# Altium schematic sheet IO_Expander.SchDoc(IO_Expander)
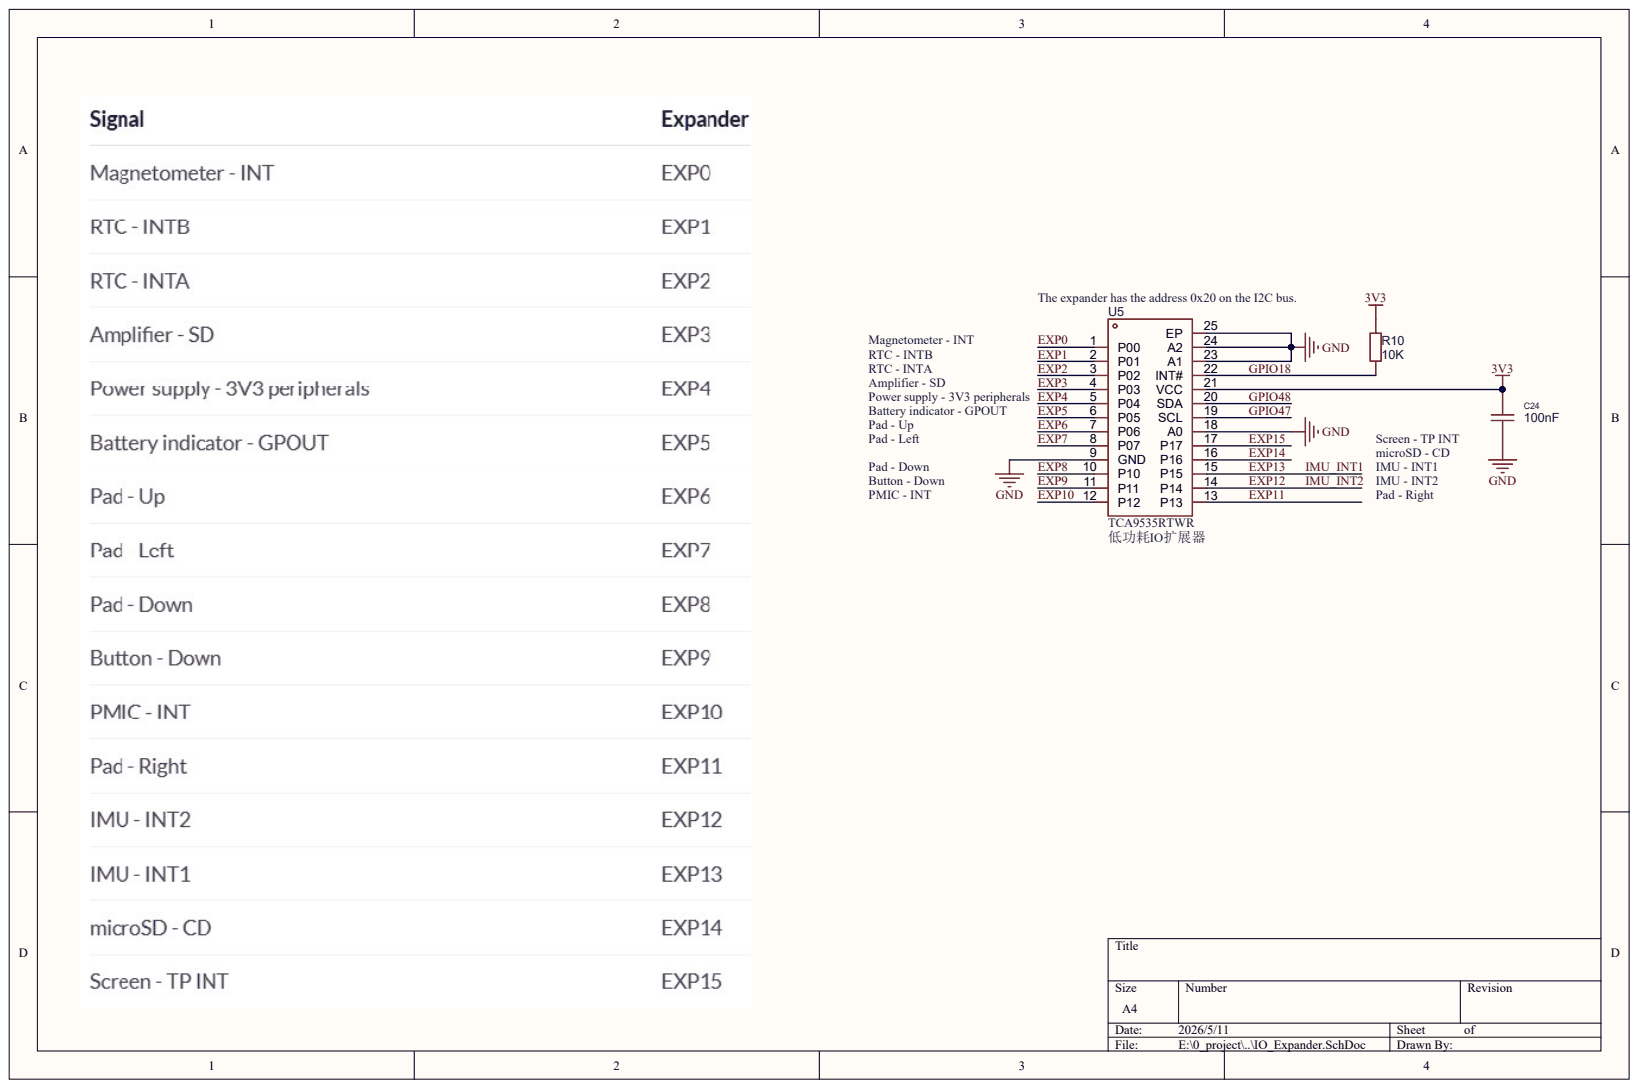
[diagram p0: IO_Expander.SchDoc]

## Parts
C24  100nF
R10  10K
U5  TCA9535RTWR

## Nets
3V3: C24.2 R10.2 U5.21(VCC)
GND: C24.1 U5.9(GND) U5.18(A0) U5.23(A1) U5.24(A2) U5.25(EP)
EXP0: U5.1(P00)
EXP1: U5.2(P01)
EXP2: U5.3(P02)
EXP3: U5.4(P03)
EXP4: U5.5(P04)
EXP5: U5.6(P05)
EXP6: U5.7(P06)
EXP7: U5.8(P07)
EXP8: U5.10(P10)
EXP9: U5.11(P11)
EXP10: U5.12(P12)
EXP11: U5.13(P13)
EXP12: U5.14(P14)
EXP13: U5.15(P15)
EXP14: U5.16(P16)
EXP15: U5.17(P17)
GPIO18: R10.1 U5.22(INT#)
GPIO47: U5.19(SCL)
GPIO48: U5.20(SDA)

## Library data of the parts
C24 = (no symbol name): Symbol: GRM155R71H104KE14D; AddInBom: yes; ModelId: 36a51122f27c49738f86b3bfa98863e0; ModelName: C0402_L1.0-W0.5-H0.5; ModelTransform: 39.37,19.685,0,0,0,0,0,0,0; Voltage Rated: 50V; Temperature Coefficient: X7R; Value: 100nF; Device: GRM155R71H104KE14D; Supplier: LCSC; Manufacturer Part: GRM155R71H104KE14D; Capacitance: 100nF; Supplier Part: C77020; Supplier Footprint: 0402; Datasheet: https://atta.szlcsc.com/upload/public/pdf/source/20180326/C77020_39BD49C951FF1AF0DB1F1FAC5AC1E401.pdf; ConvertToPcb: yes; FootprintName: R0402
R10 = (no symbol name): Device: 0402WGF4990TCE; AddInBom: yes; ModelId: 671aefced39d4c318694bba8bd8efd39; ModelName: R0402_L1.0-W0.5-H0.5; ModelTransform: 39.37,19.685,0,0,0,0,0,0.0005,0; Power(Watts): 62.5mW; Overload Voltage (Max): 50V; Value: 10K; Symbol: 0402WGF4990TCE; SupplierPart: C4125; ManufacturerPart: 0402WGF4990TCE; Supplier Footprint: 0402; JLCPCB Part Class: Extended Part; Datasheet: https://atta.szlcsc.com/upload/public/pdf/source/20200306/C422600_1E6D84923E4A46A82E41ADD87F860B5C.pdf; Supplier: LCSC; ConvertToPcb: yes; FootprintName: R0402
U5 = (no symbol name): Symbol: TCA9555RTWR
